# Altium schematic sheet POWER.SchDoc
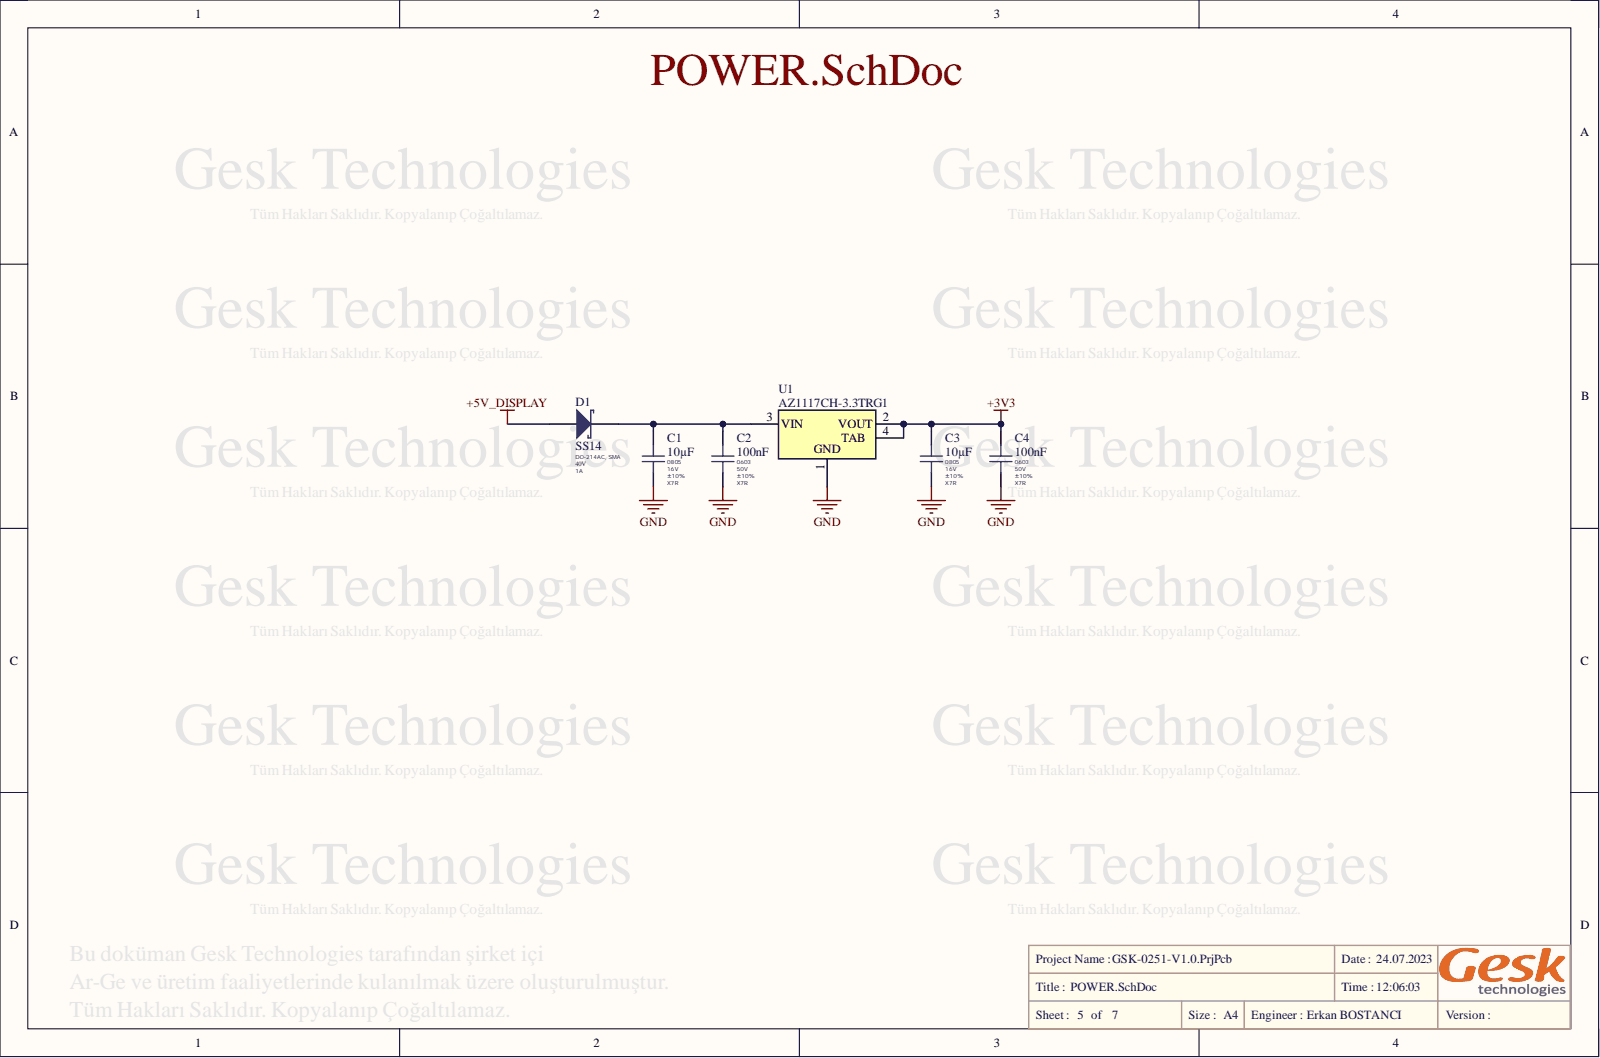
[diagram p0: POWER.SchDoc]

## Parts
C1  10µF  Package / Case: 0805  Temperature Coefficient: X7R  Tolerance: ±10%  Voltage: 16V  [SM/C0805N]
C2  100nF  Package / Case: 0603  Temperature Coefficient: X7R  Tolerance: ±10%  Voltage: 50V  [SM/C0603N]
C3  10µF  Package / Case: 0805  Temperature Coefficient: X7R  Tolerance: ±10%  Voltage: 16V  [SM/C0805N]
C4  100nF  Package / Case: 0603  Temperature Coefficient: X7R  Tolerance: ±10%  Voltage: 50V  [SM/C0603N]
D1  SS14  Voltage - DC Reverse (Vr) (Max): 40V  [DO-214AC, SMA]
U1  AZ1117CH-3.3TRG1  [SOT-223-4]

## Nets
+3V3: C3.1 C4.1 U1.2(VOUT) U1.4(TAB)
+5V_DISPLAY: D1.1
GND: C1.2 C2.2 C3.2 C4.2 U1.1
NetC1_1: C1.1 C2.1 D1.2 U1.3(VIN)

## Library data of the parts
C1 C3 = CapNP: CAP CER 10UF 16V X7R 0805; Manufacturer Part Number: smd cap; Component Kind: Standard; Signal Integrity: Cap; Simulation: CAP; Value: 10µF; Library Name: Passives_GESK.IntLib
C2 C4 = CapNP: CAP CER 0.1UF 50V X7R 0603; Manufacturer Part Number: smd cap; Component Kind: Standard; Signal Integrity: Cap; Simulation: CAP; Value: 100nF; Library Name: Passives_GESK.IntLib
D1 = D Schottky: DIODE SCHOTTKY 1A 40V DO-214AC SMA; Manufacturer: HOTTECH; Voltage - Forward (Vf) (Max) @ If: 0.55V; Component Kind: Standard; Current - Average Rectified (Io): 1A; Manufacturer Part Number: SS14; Mounting Type: SMD; Package / Case: DO-214AC, SMA; Library Name: Diodes_GESK.IntLib
U1 = AZ1117CH-3.3TRG1: IC REG LDO 3.3V 0.8A SOT223; Manufacturer: Diodes Incorporated; Current - Output: 800mA; Topology: Linear; Values: 3.3V 800mA; Voltage - Dropout (Typical): 1.2V @ 800mA; Voltage - Input: Up to 15V; Category: IC - Voltage Regulator; Component Kind: Standard; Library Name: Voltage_Regulator.IntLib; Manufacturer Part Number: AZ1117CH-3.3TRG1; Mounting Type: Surface Mount; Package / Case: TO-261-4, TO-261AA, SOT-223; URL: https://www.digikey.com/product-detail/en/diodes-incorporated/AZ1117CH-3.3TRG1/AZ1117CH-3.3TRG1DICT-ND/4505206; Voltage - Output: 3.3V
